# Altium schematic sheet 555TimerOrganFinal.SchDoc(555TimerOrganFinal)
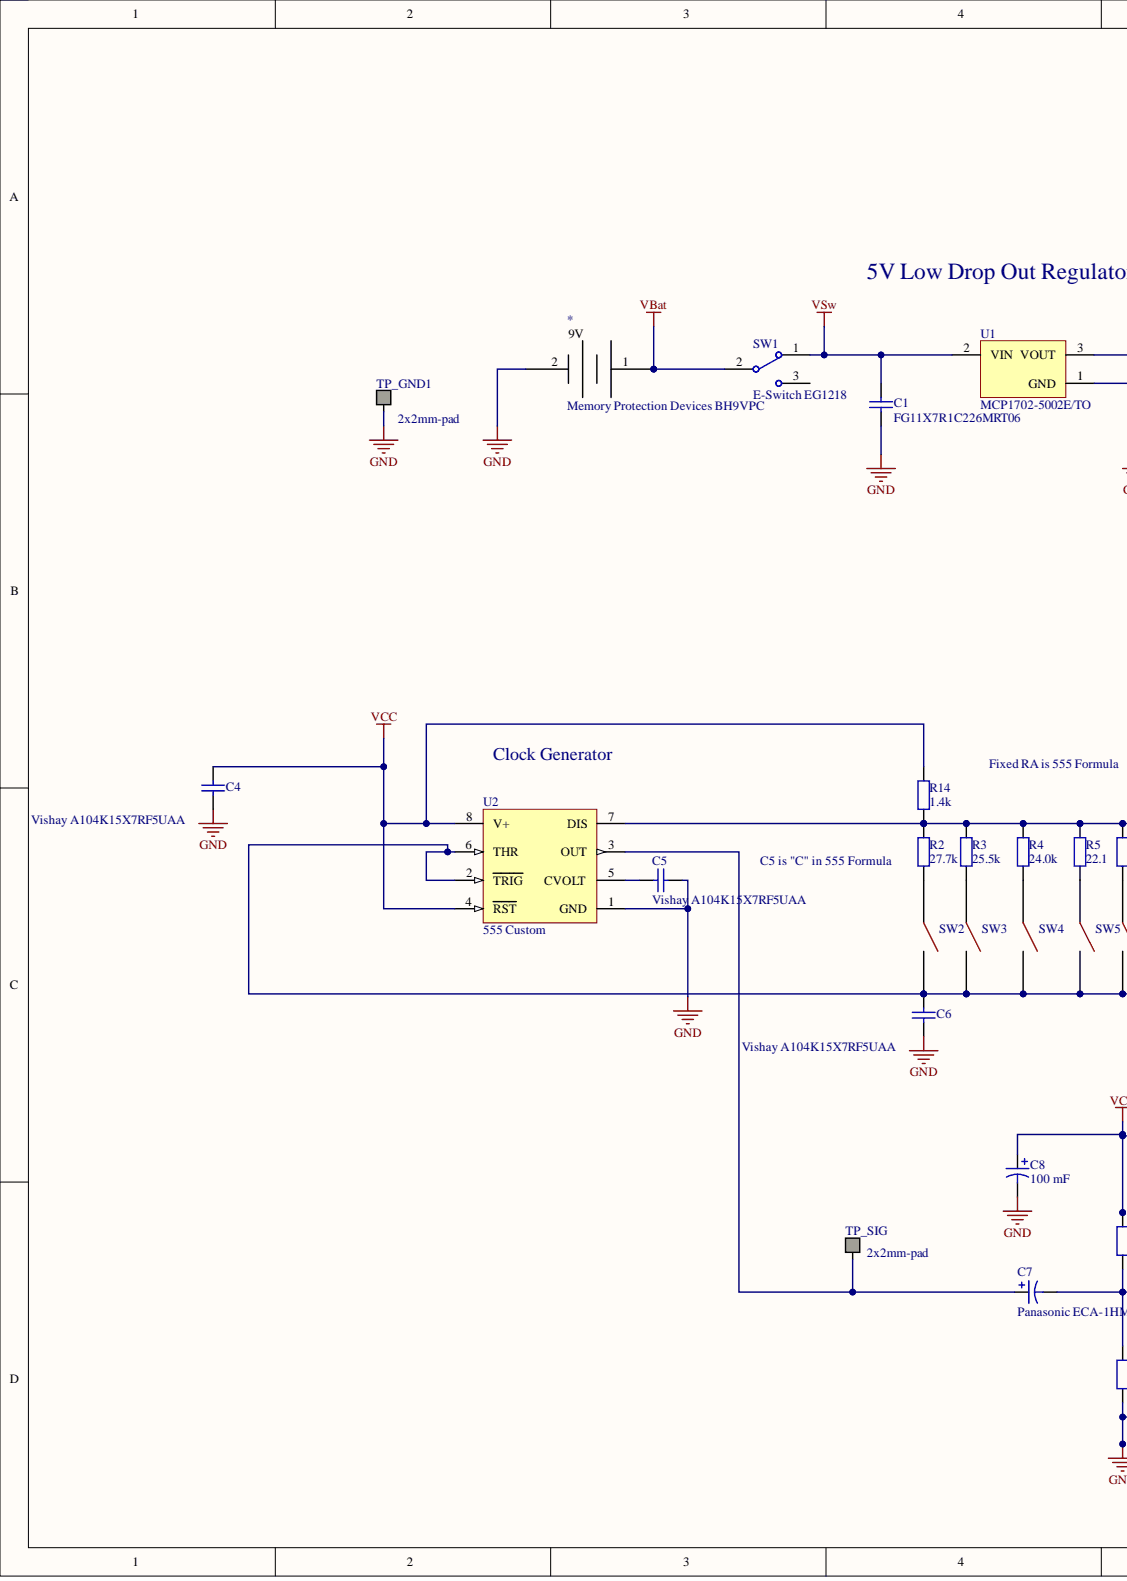
[diagram p0: 555TimerOrganFinal.SchDoc, left]
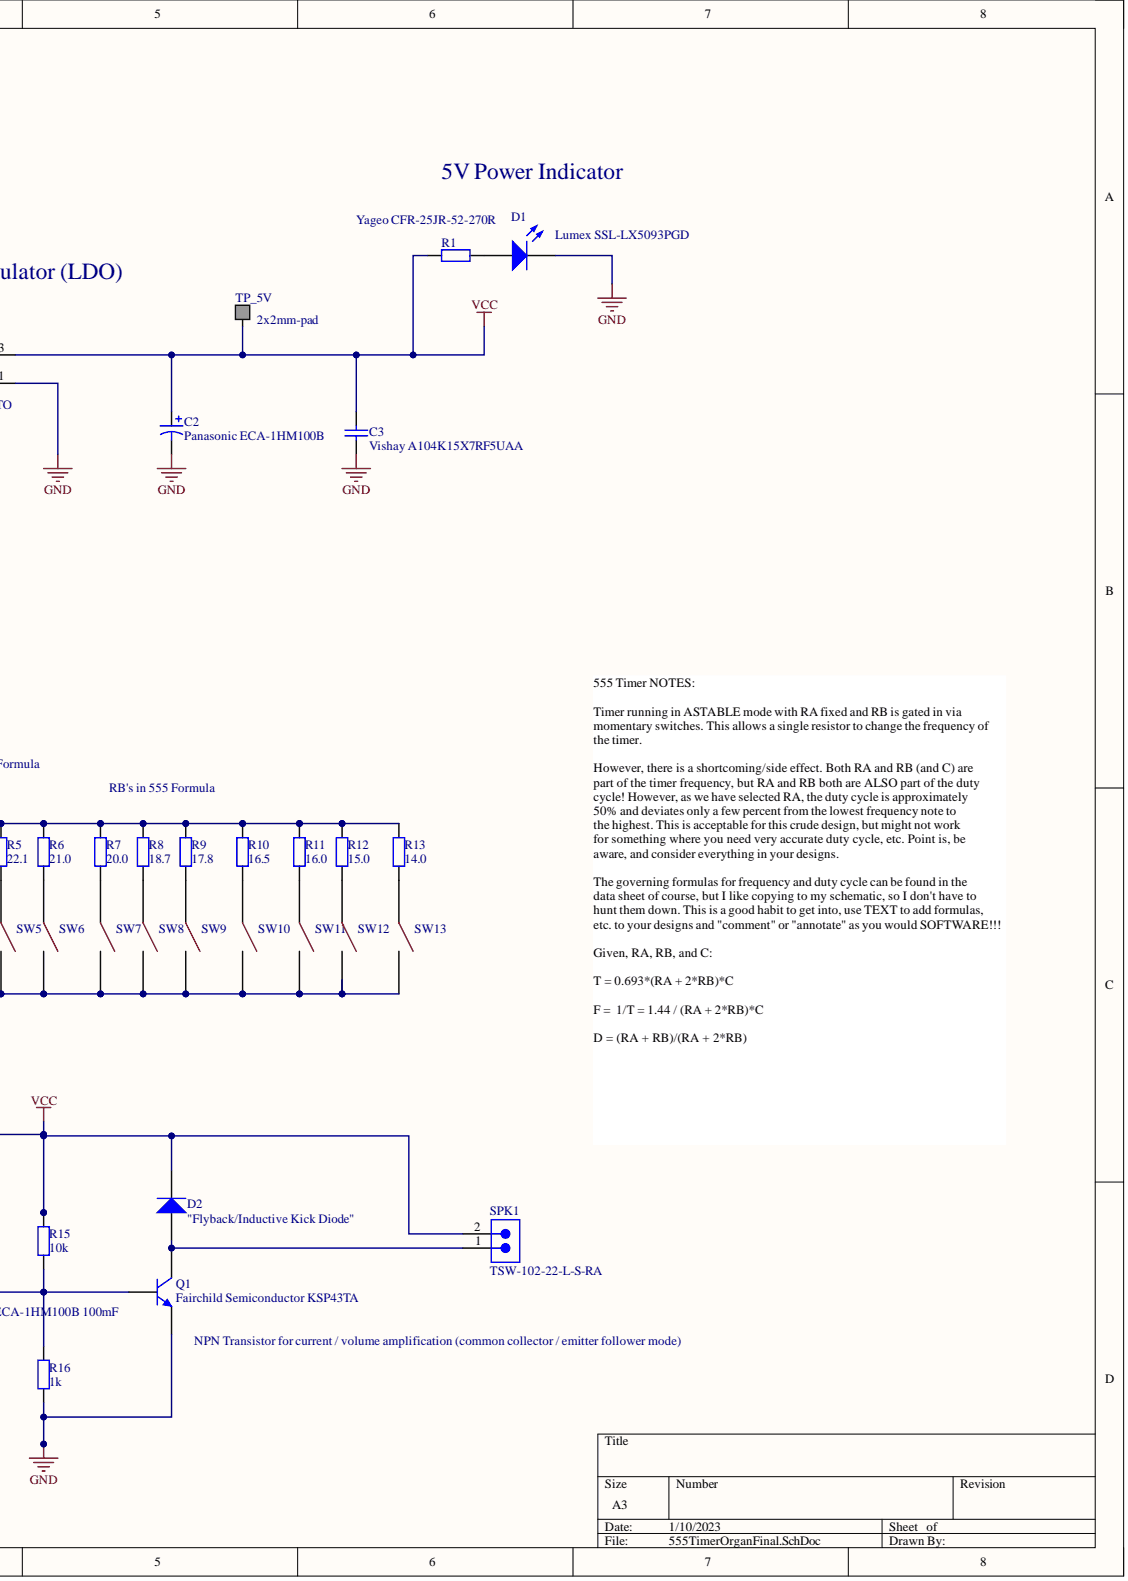
[diagram p1: 555TimerOrganFinal.SchDoc, right]

## Parts
*  Memory Protection Devices BH9VPC
C1  FG11X7R1C226MRT06  [PCB-5ntz87ifb63asdvwakhk-1]
C2  Panasonic ECA-1HM100B  [PCB-gj8d6f3b5shxg0pxc8is-1]
C3  Vishay A104K15X7RF5UAA  [PCB-a7lere1682ddwu6vd5r6-1]
C4  Vishay A104K15X7RF5UAA  [PCB-a7lere1682ddwu6vd5r6-1]
C5  Vishay A104K15X7RF5UAA  [PCB-a7lere1682ddwu6vd5r6-1]
C6  Vishay A104K15X7RF5UAA  [PCB-a7lere1682ddwu6vd5r6-1]
C7  Panasonic ECA-1HM100B 100mF  Manufacturer Part Number: ECA-1HM100B  [PCB-gj8d6f3b5shxg0pxc8is-1]
C8  100 mF  [PCB-gj8d6f3b5shxg0pxc8is-1]
D1  Lumex SSL-LX5093PGD  [PCB-6hmn14p3euwrtpae4j9f-3]
D2  "Flyback/Inductive Kick Diode"
Q1  Fairchild Semiconductor KSP43TA
R1  Yageo CFR-25JR-52-270R
R2  27.7k
R3  25.5k
R4  24.0k
R5  22.1
R6  21.0
R7  20.0
R8  18.7
R9  17.8
R10  16.5
R11  16.0
R12  15.0
R13  14.0
R14  1.4k
R15  10k
R16  1k
SPK1  TSW-102-22-L-S-RA
SW1  E-Switch EG1218  Manufacturer Part Number: EG1218
SW2  Panasonic EVQ-21405R  (value not drawn)
SW3  Panasonic EVQ-21405R  (value not drawn)
SW4  Panasonic EVQ-21405R  (value not drawn)
SW5  Panasonic EVQ-21405R  (value not drawn)
SW6  Panasonic EVQ-21405R  (value not drawn)
SW7  Panasonic EVQ-21405R  (value not drawn)
SW8  Panasonic EVQ-21405R  (value not drawn)
SW9  Panasonic EVQ-21405R  (value not drawn)
SW10  Panasonic EVQ-21405R  (value not drawn)
SW11  Panasonic EVQ-21405R  (value not drawn)
SW12  Panasonic EVQ-21405R  (value not drawn)
SW13  Panasonic EVQ-21405R  (value not drawn)
TP_5V  2x2mm-pad
TP_GND1  2x2mm-pad
TP_SIG  2x2mm-pad
U1  MCP1702-5002E/TO
U2  555 Custom

## Nets
GND: *.2 C1.1 C2.2 C3.1 C4.1 C5.1 C6.1 C8.2 D1.2 Q1.1 R16.1 TP_GND1.1 U1.1 U2.1(GND)
VBat: *.1 SW1.2
VCC: C2.1 C3.2 C4.2 C8.1 D2.2 R1.1 R14.2 R15.2 SPK1.2 TP_5V.1 U1.3 U2.4(RST) U2.8(V+)
VSw: C1.2 SW1.1 U1.2
NetC5_2: C5.2 U2.5(CVOLT)
NetC6_2: C6.2 SW2.2 SW3.2 SW4.2 SW5.2 SW6.2 SW7.2 SW8.2 SW9.2 SW10.2 SW11.2 SW12.2 SW13.2 U2.2(TRIG) U2.6(THR)
NetC7_1: C7.1 TP_SIG.1 U2.3(OUT)
NetC7_2: C7.2 Q1.2 R15.1 R16.2
NetD1_1: D1.1 R1.2
NetD2_1: D2.1 Q1.3 SPK1.1
NetR2_1: R2.1 SW2.1
NetR2_2: R2.2 R3.2 R4.2 R5.2 R6.2 R7.2 R8.2 R9.2 R10.2 R11.2 R12.2 R13.2 R14.1 U2.7(DIS)
NetR3_1: R3.1 SW3.1
NetR4_1: R4.1 SW4.1
NetR5_1: R5.1 SW5.1
NetR6_1: R6.1 SW6.1
NetR7_1: R7.1 SW7.1
NetR8_1: R8.1 SW8.1
NetR9_1: R9.1 SW9.1
NetR10_1: R10.1 SW10.1
NetR11_1: R11.1 SW11.1
NetR12_1: R12.1 SW12.1
NetR13_1: R13.1 SW13.1

Not connected: SW1 3

## Library data of the parts
* = CMP-8a8e42df17d25549-3: 9V cell holder w/ PC pins (80 pcs per tray); Component Kind: Standard; Library Name: My Personal Space; Manufacturer Part Number: BH9VPC; Octopart URL: http://octopart.com/bh9vpc-memory+protection+devices-22788154; OctopartID: 8a8e42df17d25549
C1 = CMP-b6f17bf572e46936-1: Commercial Grade, Halogen Free Dipped Radial / Ammo Pack; Manufacturer: TDK Corporation; Manufacturer Part Number: FG20X7R1H106KRT06; Capacitance: 10 μF; Pitch: 5 mm; Tolerance: ±10%; Voltage Rating: 50 V; Component Kind: Standard; Library Name: My Personal Space; Octopart URL: http://octopart.com/fg20x7r1h106krt06-tdk-68084083; OctopartID: b6f17bf572e46936
C2 C7 = CMP-7469be2ed7d4abae-12: Cap Aluminum 10uF 50V 20% (2.5v6 or 2.5 X 11mm) Radial Aluminum Cylindrical Can p=5x6 mm 65mA 2000 hr 85°C Ammo Pack; Capacitance: 10.0 µF; Lead space: 0.002m; Lead-Free Status: Lead Free; Voltage Rating (DC): 50.0 V; Capacitance Tolerance: ±20%; Component Kind: Standard; Library Name: My Personal Space; Lifecycle Status: Not Listed by Manufacturer; Mounting Style: Through Hole; Octopart URL: http://octopart.com/eca-1hm100b-panasonic-55412443; OctopartID: 7469be2ed7d4abae; Packaging: Cut Tape (CT); RoHS: Compliant; Size-Diameter: 0.005 m; Size-Height: 0.011 m; Size-Length: 0.011 m
  C2: Manufacturer Part Number: ECA-1HM100B
C3-C6 = CMP-58c2934969338705-2: Cap Ceramic 0.1uF 50V X7R 10% Axial 125°C Ammo Pack; Component Kind: Standard; Library Name: My Personal Space
C8 = CMP-7469be2ed7d4abae-12: Cap Aluminum 10uF 50V 20% (2.5v6 or 2.5 X 11mm) Radial Aluminum Cylindrical Can p=5x6 mm 65mA 2000 hr 85°C Ammo Pack; Manufacturer Part Number: ECA-1HM100B; Capacitance: 10.0 µF; Lead space: 0.002m; Lead-Free Status: Lead Free; Voltage Rating (DC): 50.0 V; Capacitance Tolerance: ±20%; Component Kind: Standard; Library Name: My Personal Space; Lifecycle Status: Not Listed by Manufacturer; Mounting Style: Through Hole; Octopart URL: http://octopart.com/eca-1hm100b-panasonic-55412443; OctopartID: 7469be2ed7d4abae; Packaging: Cut Tape (CT); RoHS: Compliant; Size-Diameter: 0.005 m; Size-Height: 0.011 m; Size-Length: 0.011 m
D1 = CMP-4a898382afec954e-1: Green 5 mm 60° Diffused 30 mcd 2.2 V Through Hole LED - T-1¾; Forward Voltage: 2.20 V; Lead-Free Status: Lead Free; Luminous Intensity: 30.0 mcd; Peak Wavelength: 555 nm; Color: Green; Component Kind: Standard; Lens Color: Green; Lens Type: Diffused; Library Name: My Personal Space; Manufacturer Part Number: SSL-LX5093PGD; Mounting Style: Through Hole, Vertical; Number of Pins: 2; Octopart URL: https://octopart.com/ssl-lx5093pgd-lumex-114691; OctopartID: 4a898382afec954e; Operating Temperature: 85.0 °C (max); Packaging: Bulk; RoHS: Compliant; Size-Diameter: 5.00 mm; Size-Height: 8.60 mm; Viewing Angle: 60 °; Wavelength: 555 nm
D2 = 1N4001-TP: 1N4001 Series 1 A 400 V Through-Hole Rectifying Diode - DO-41; Capacitance: 15.0 pF; Current Rating: 1 A; Lead-Free Status: Lead Free; Polarity: Standard; Voltage Rating (DC): 50 V; Case/Package: DO-41; Component Kind: Standard; Library Name: Octopart library; Manufacturer Part Number: 1N4001-TP; Mounting Style: Through Hole; Octopart URL: http://octopart.com/1n4001-tp-mcc-730241; OctopartID: 504e994f51a98f89; Packaging: Cut Tape (CT), Tape & Reel (TR); RoHS: Compliant
Q1 = CMP-b97c7073e89f66bb-1: Trans GP BJT NPN 200V 0.5A 3-Pin TO-92 Ammo; Lead-Free Status: Lead Free; Voltage Rating (DC): 200.0 V; Case/Package: TO-92; Component Kind: Standard; Current Rating: 0.5 A; Library Name: My Personal Space; Lifecycle Status: Active; Manufacturer Part Number: KSP43TA; Mounting Style: Through Hole; Octopart URL: http://octopart.com/ksp43ta-fairchild+semiconductor-357509; OctopartID: b97c7073e89f66bb; Packaging: Tape; RoHS: Compliant
R1-R16 = CMP-41218973057a9ee6-4: CFR Series 0.25 W 470 Ohm ±5 % -350 to 500 ppm/°C Carbon Film Resistor; Manufacturer Part Number: CFR-25JR-52-470R; Lead-Free Status: Lead Free; Component Kind: Standard; Library Name: My Personal Space; Octopart URL: http://octopart.com/cfr-25jr-52-470r-yageo-22569277; OctopartID: 41218973057a9ee6; RoHS: Compliant
SPK1 = TSW-102-22-L-S-RA: 0.025" SQ Post Header, Through-hole, Right Angle, -55 to 125 degC, 2.54 mm Pitch, 2-Pin, Male, RoHS; Number of Contacts: 2; Pin Pitch: 0.00254 m; Component Kind: Standard; Contact Material: Phosphor Bronze; Contact Plating: Gold; Gender: Male; Library Name: Octopart library; Mounting Style: Through Hole; Number of Rows: 1; Octopart Url: https://octopart.com/tsw-102-22-l-s-ra-samtec-11758137; OctopartID: 0b86c23f44d9d441; REACH SVHC Compliance: No SVHC; RoHS: Compliant
SW1 = CMP-9a5a5340a2f5459d-7: Slide Switch, 500 V, -20 to 70 degC, 3-Pin THD, RoHS; Actuator Type: Standard; Contacts Type: SPDT; Current Rating: 200 mA; Lead-Free Status: Lead Free; Number of Positions: 2; Component Kind: Standard; Contact Material: Copper Alloy; Contact Plating: Silver; DatasheetVersion: Oct-15; Library Name: FavoritesLibrary; Mounting Style: PCB, Through Hole; Octopart Url: http://octopart.com/eg1218-e-switch-1056201; OctopartID: 9a5a5340a2f5459d; Orientation: Vertical; PackageDescription: 3-Pin Through Hole Device, Body 11.6 x 4 mm, Pitch 2.5 mm; PackageReference: EG1218; Packaging: Bulk; RoHS: Compliant; Seller Landing  Url: https://octopart-clicks.com/click/track?country=US&ak=8157f166&sig=0e9adab&sid=459&ppid=1056201&vpid=1179261&ct=offers; Size-Height: 5.40 mm; Size-Length: 2.03 mm; Size-Width: 4.00 mm
SW2-SW13 = EVQ-21405R: Switch Tactile N.O. SPST Round Button PC Pins 0.02A 15VDC 1.3N Thru-Hole Radial T/R; Component Kind: Standard; Element14 Part No.: 2281982; Library Name: Octopart library; Mounting Style: Through Hole, PC Board; Octopart URL: http://octopart.com/evq-21405r-panasonic-55650591; RoHS: Compliant; Size-Length: 6.00 mm; Size-Width: 6.00 mm
TP_5V TP_GND1 TP_SIG = RCT-0C: Raychem; SMT Circuit probe pad, 0805, 0R0 RCT; Component Kind: Standard; Library Name: Octopart library
U1 = MCP1702-5002E/TO: 250 mA Low Quiescent Current LDO Regulator, 3-Pin TO-92, Extended TemperatureBAG; Dropout Voltage: 0.33 V; Lead-Free Status: Lead Free; Number of Outputs: 1; Output Voltage: 5.0 V; Quiescent Current: 2e-06 A; Case/Package: TO-92; Component Kind: Standard; Library Name: Octopart library; Lifecycle Status: Active; Mounting Style: Through Hole; Number of Pins: 3; Octopart Url: https://octopart.com/mcp1702-5002e%2Fto-microchip-535762; OctopartID: b1707872b8c46eb7; Packaging: Bulk; REACH SVHC Compliance: No SVHC; RoHS: Compliant
U2 = CMP-0056-00035-9: timer; Manufacturer Part Number: LMC555CN; Frequency: 3000000.0 Hz; Lead-Free Status: Contains Lead; Quiescent Current: 5e-05 A; Component Kind: Standard; Library Name: My Personal Space; Lifecycle Status: Active; Number of Pins: 8; Octopart Url: https://octopart.com/lmc555cn-texas+instruments-9341523; OctopartID: d11b189f7e2e0edc; Packaging: Rail; REACH SVHC Compliance: No SVHC; RoHS: Non-Compliant
